# Altium schematic sheet Thruster_Power.SchDoc
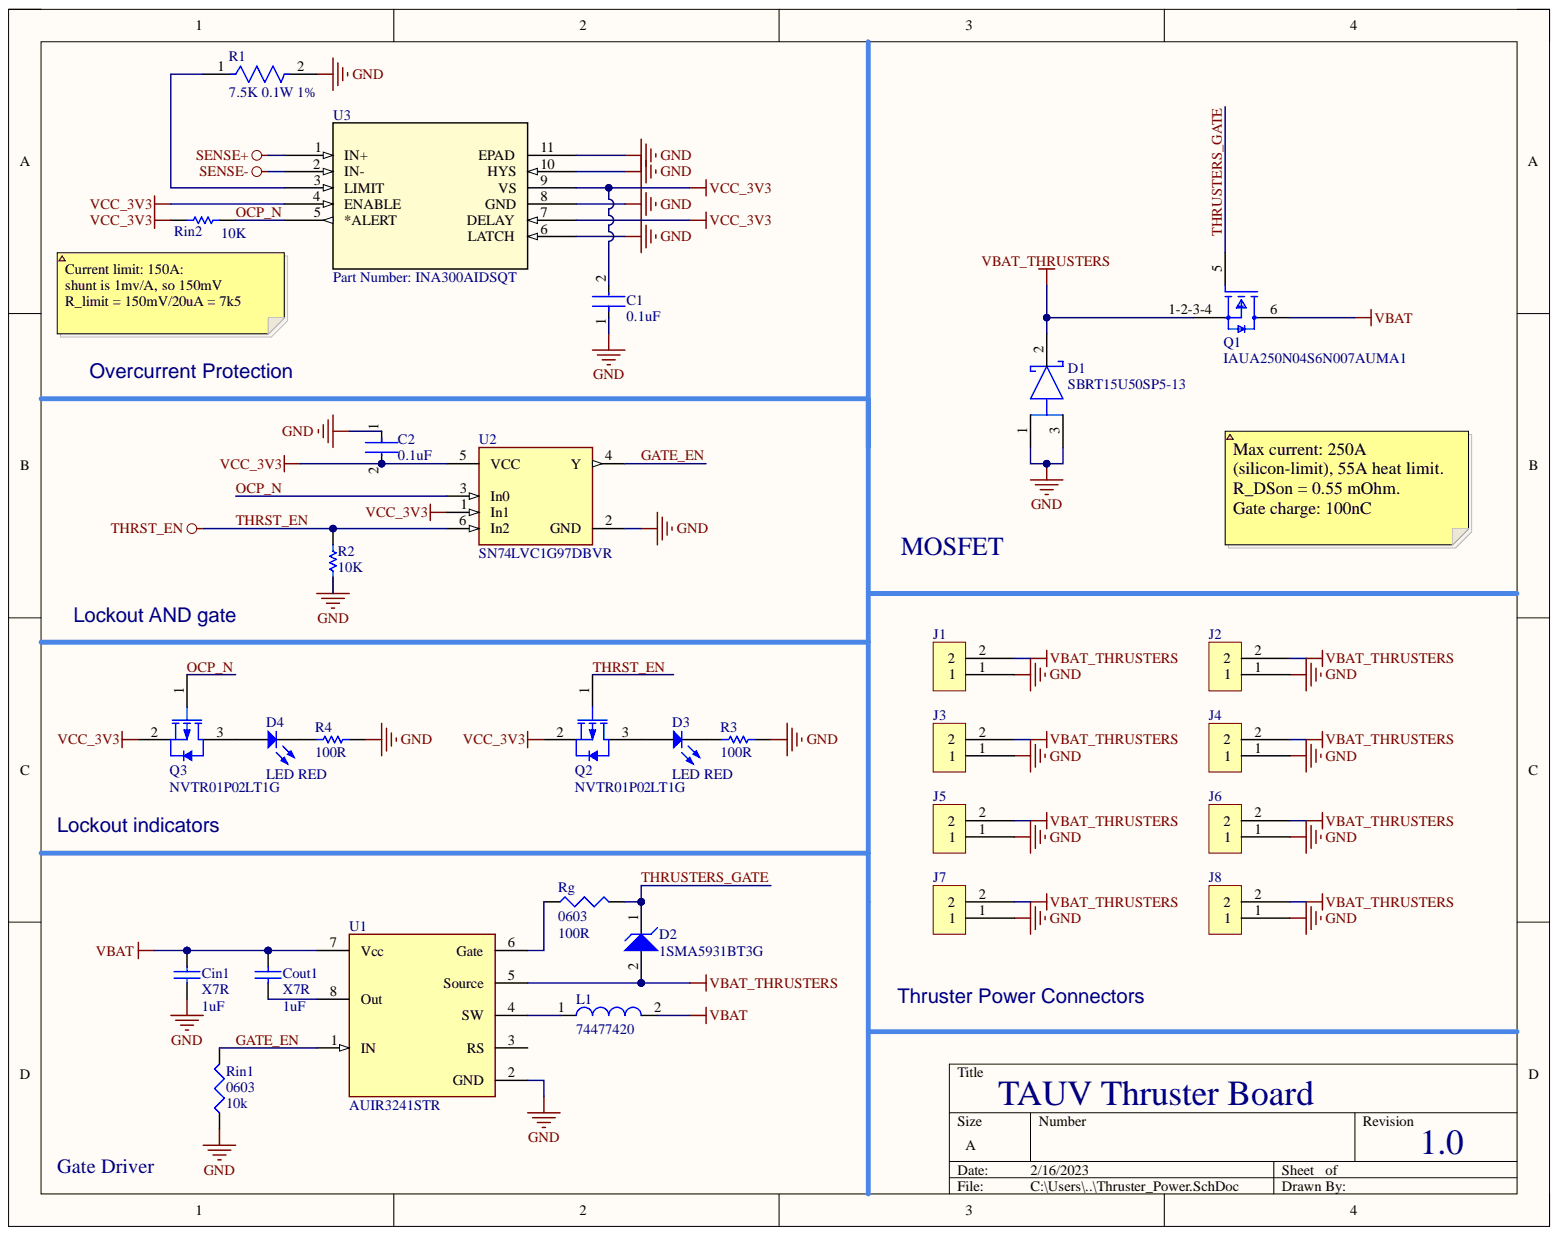
[diagram p0: Thruster_Power.SchDoc]

## Parts
C1  0.1uF  [FP-C0603C-CF-MFG]
C2  0.1uF  [FP-C0603C-CF-MFG]
Cin1  X7R  value 1uF  [C0805]
Cout1  X7R  value 1uF  [C0805]
D1  SBRT15U50SP5-13  [FP-PowerDI5-MFG]
D2  1SMA5931BT3G  [ONSC-SMA-2-403D-02_F_V]
D3  LED RED  [C0805]
D4  LED RED  [C0805]
J1  (no value)  [2-pin Megafit RA]
J2  (no value)  [2-pin Megafit RA]
J3  (no value)  [2-pin Megafit RA]
J4  (no value)  [2-pin Megafit RA]
J5  (no value)  [2-pin Megafit RA]
J6  (no value)  [2-pin Megafit RA]
J7  (no value)  [2-pin Megafit RA]
J8  (no value)  [2-pin Megafit RA]
L1  74477420  [WE-PD2-M]
Q1  IAUA250N04S6N007AUMA1  [FP-PG-HSOF-5-MFG]
Q2  NVTR01P02LT1G  [ONSC-SOT-23-3-318-08_V]
Q3  NVTR01P02LT1G  [ONSC-SOT-23-3-318-08_V]
R1  7.5K 0.1W 1%  Resistance Tolerance: 1%  [FP-ERJ3EK-MFG]
R2  10K  [RESC0603(1608)_N]
R3  100R  [RESC1609X50X30NL10T20]
R4  100R  [RESC1609X50X30NL10T20]
Rg  0603  value 100R  [J1-0603]
Rin1  0603  value 10k  [J1-0603]
Rin2  10K  [RESC0603(1608)_N]
U1  AUIR3241STR  [FP-PG-DSO-8-MFG]
U2  SN74LVC1G97DBVR  [FP-DBV0006A-MFG]
U3  Part Number: INA300AIDSQT  [DSQ10_P9X1P5]

## Nets
GND: C1.1 C2.1 Cin1.1 D1.1 D1.3 J1.1 J2.1 J3.1 J4.1 J5.1 J6.1 J7.1 J8.1 R1.2 R2.2 R3.2 R4.2 Rin1.1 U1.2(GND) U2.2(GND) U3.6(LATCH) U3.8(GND) U3.10(HYS) U3.11(EPAD)
SENSE+: U3.1(IN+)
SENSE-: U3.2(IN-)
VBAT: Cin1.2 Cout1.2 L1.2 Q1.6 U1.7(Vcc)
VBAT_THRUSTERS: D1.2 D2.2 J1.2 J2.2 J3.2 J4.2 J5.2 J6.2 J7.2 J8.2 Q1.1-2-3-4 U1.5(Source)
VCC_3V3: C1.2 C2.2 Q2.2 Q3.2 Rin2.2 U2.1(In1) U2.5(VCC) U3.4(ENABLE) U3.7(DELAY) U3.9(VS)
GATE_EN: Rin1.2 U1.1(IN) U2.4(Y)
OCP_N: Q3.1 Rin2.1 U2.3(In0) U3.5(*ALERT)
THRST_EN: Q2.1 R2.1 U2.6(In2)
THRUSTERS_GATE: D2.1 Q1.5 Rg.2
NetCout1_1: Cout1.1 U1.8(Out)
NetD3_1: D3.1 R3.1
NetD3_2: D3.2 Q2.3
NetD4_1: D4.1 R4.1
NetD4_2: D4.2 Q3.3
NetL1_1: L1.1 U1.4(SW)
NetR1_1: R1.1 U3.3(LIMIT)
NetRg_1: Rg.1 U1.6(Gate)

Not connected: U1 3(RS)

## Library data of the parts
C1 C2 = CMP-1035-02580-3: CAP CER 0.1UF 10V X7R 0603; Manufacturer: KEMET; Manufacturer Part Number: C0603C104K8RACTU; Automotive Grade: Grade 1; Capacitance: 0.10 μF; Material: Ceramic; Temperature Coefficient: 30ppm/°C; Tolerance: 0.1; Value: 0.10 μF; Automotive: Yes; Category: Cap; Component Kind: Standard; ComponentLink2Description: Datasheet; ComponentLink2URL: https://api.kemet.com/component-edge/download/datasheet/C0603C100J5GACAUTO.pdf; Device Class L1: Passive Components; Device Class L2: Capacitors; Device Class L3: Ceramic Capacitors; Digikey Description: CAP CER 0.1UF 10V X7R 0603; Height: 0.87mm; Lead Free: Yes; Library Name: Altium Content Vault; Mouser Description: Multilayer Ceramic Capacitors MLCC - SMD/SMT 10volts 0.1uF X7R 10%; Octopart: https://octopart.com/c0603c104k8ractu-kemet-145075; Package: C0603C-CF; RoHS: TRUE; Temperature: -55°C to +125°C; Temperature Characteristic: X7R; Temperature Range High: +125°C; Temperature Range Low: -55°C; Voltage Rating: 10 V
Cin1 Cout1 = Cap: Capacitor; Component Kind: Standard; LatestRevisionDate: 17-Jul-2002; LatestRevisionNote: Re-released for DXP Platform.; Library Name: Miscellaneous Devices.IntLib; PackageDescription: Radial Cap, Thru-Hole; 2 Leads; 0.3 in Pin Spacing; PackageReference: RAD-0.3; Published: 8-Jun-2000; Signal Integrity: Cap; Simulation: CAP; Publisher: Altium Limited
D1 = CMP-12791-000016-1: 50V 15A Rectifier Schottky Diode; Manufacturer: Diodes Inc.; Manufacturer Part Number: PDS3200-13; Forward Current: 3A; Max Junction Temp: +150°C; Max Repetitive Reverse Voltage: 200V; Max Surge Forward Current: 180A; Peak Reverse Current: 1uA; Standoff Height: 0mm; Automotive: No; Category: Diode; Component Kind: Standard; ComponentLink2Description: Datasheet; ComponentLink2URL: https://www.diodes.com/assets/Datasheets/ds30470.pdf; Device Class L1: Discrete Semiconductors; Device Class L2: Diodes; Device Class L3: Rectifier Diodes; Digikey Description: DIODE SCHOTTKY 200V 3A POWERDI5; Footprint Url: https://www.diodes.com/assets/Package-Files/PowerDI5.pdf; Height: 1.15mm; Lead Free: Yes; Library Name: Altium Content Vault; Mouser Description: PDS3200 Series 200 V 3 A High Voltage Schottky Barrier Rectifier - PowerDI-5; Octopart: https://octopart.com/pds3200-13-diodes+inc.-332544; Package: PowerDI5; Reverse Voltage: 200V; RoHS: TRUE; Temperature: -65°C to +150°C; Temperature Range High: +150°C; Temperature Range Low: -65°C
D2 = CMP-1060-00118-1: 1.5 W Zener Voltage Regulator, 2-Pin SMA, Pb-Free, Tape and Reel; Manufacturer: On Semiconductor; VZ Typ (V): 18; Component Kind: Standard; ComponentLink1Description: Manufacturer URL; ComponentLink1URL: http://www.onsemi.com/; ComponentLink2Description: Datasheet; ComponentLink2URL: http://www.onsemi.com/pub_link/Collateral/1SMA5913BT3-D.PDF; ComponentLink3Description: Package Specification; ComponentLink3URL: http://www.onsemi.com/pub_link/Collateral/1SMA5913BT3-D.PDF; DatasheetVersion: Rev. 8; Library Name: Altium Content Vault; Mounting Technology: Surface Mount; PackageDescription: 2-Pin Small Molded Package, J-Bend Lead, Body 2.605 x 4.315 mm; PackageReference: SMA-2-403D-02_F; PackageVersion: Rev. F, 11/2008; Packing: Tape and Reel; PartNumber: 1SMA5931BT3G; RoHS: Pb-Free
D3 D4 = LED0: led   LTST-C171KRKT; Manufacturer: Lite-On Inc.; Current - Test: 20mA; Lens Color: Colorless; Lens Style/Size: Rectangle with Flat Top, 1.40mm x 1.25mm; Lens Transparency: Clear; Millicandela Rating: 54mcd; Viewing Angle: 130°; Voltage - Forward (Vf) (Typ): 2V; Wavelength - Dominant: 631nm; Wavelength - Peak: 639nm; Category: Optoelectronics; Color: Red; Component Kind: Standard; ComponentLink1Description: http://optoelectronics.liteon.com/upload/download/DS22-2000-109/LTST-C171KRKT.pdf; ComponentLink1URL: http://optoelectronics.liteon.com/upload/download/DS22-2000-109/LTST-C171KRKT.pdf; Features: -; Height (Max): 0.80mm; Manufacturer Part Number: LTST-C171KRKT; Mounting Type: Surface Mount; Package / Case: 0805 (2012 Metric); Packaging: Cut Tape (CT); Part Status: Active; Pricing 1: 1=0.31, 10=0.225, 25=0.1656, 100=0.1183, 250=0.0804, 500=0.07096, 1000=0.05558 (USD); RoHS: RoHS Compliant; Simulation: LED0; Size / Dimension: 2.00mm L x 1.25mm W; Stock 1: 260544; Supplier 1: Digi-Key; Supplier Part Number 1: 160-1427-1-ND; Library Name: CMR_Miscellaneous_Devices.IntLib
J1-J8 = 2-pin megafit: Component Kind: Standard; Library Name: TAUV-Lib.SchLib
L1 = CMP-0227-00441-1: SMD Power Inductor WE-PD2, L = 100 µH; Inductance: 100 ÂµH; Component Kind: Standard; ComponentLink1Description: Manufacturer Link; ComponentLink1URL: http://www.we-online.com; ComponentLink2Description: Datasheet; ComponentLink2URL: http://www.we-online.de/katalog/media/pdf/74477420.pdf; DatasheetVersion: ver 2, Jun-2005; Library Name: Altium Content Vault; PackageDescription: SMD Inductor, 2-Leads, Body 5.8 x 5.2 mm; PackageReference: WE-PD2-M; PackageVersion: 2005
Q1 = CMP-32901-001165-1: MOSFET_(20V 40V) PG-HSOF-5; Component Kind: Standard; Library Name: Altium Content Vault
Q2 Q3 = CMP-1058-00752-1: Power MOSFET, -20 V, -1.3 A, P-Channel, 3-Pin SOT-23, Pb-Free, Tape and Reel; Manufacturer: On Semiconductor; Channel Polarity: P Channel; Ciss Typ (pF): 225; Configuration: Single; Coss Typ (pF): 130; Crss Typ (pF): 55; ID Max (A): 1.3; PD Max (W): 0.4; Qrr Typ (nC): 8.5; V(BR)DSS Min (V): 20; VGS Max (V): 12; VGS(th) Max (V): 1.25; Component Kind: Standard; ComponentLink1Description: Manufacturer URL; ComponentLink1URL: http://www.onsemi.com/; ComponentLink2Description: Datasheet; ComponentLink2URL: http://www.onsemi.cn/pub_link/Collateral/NTR1P02LT1-D.PDF; ComponentLink3Description: Package Specification; ComponentLink3URL: http://www.onsemi.cn/pub_link/Collateral/318-08.PDF; DatasheetVersion: Rev. 13; Library Name: Altium Content Vault; Mounting Technology: Surface Mount; Package Type: SOT-23-3; PackageDescription: 3-Pin Small Outline Transistor, Body 2.92 x 1.3 mm, Pitch 0.95 mm; PackageReference: SOT-23-3-318-08; PackageVersion: Rev. AP, 11/2009; Packing: Tape and Reel; PartNumber: NVTR01P02LT1G; RoHS: Pb-Free
R1 = CMP-2000-00636-2: RES SMD 7.5K OHM 1% 1/10W 0603; Max Power Dissipation: 100mW; Resistance: 7.5kR; Temperature Coefficient: 100ppm/°C; Voltage Rating: 75V; Voltage Rating (DC): 50V; Case Code (Imperial): 0603; Case Code (Metric): 1608; Case/Package: 0603; Component Kind: Standard; Construction: Rectangular; Contact Plating: Tin; Depth: 0.8mm; Features: Automotive AEC-Q200; Height: 0.022inch; Lead Free: Lead Free; Length: 1.6mm; Library Name: Altium Content Vault; Max Operating Temperature: 155°C; Military Standard: Not; Min Operating Temperature: -55°C; Mount: Surface Mount; Number of Terminations: 2; Package Quantity: 1; Packaging: Tape and Reel; Power Rating: 100mW; Radiation Hardening: No; REACH SVHC: No SVHC; Resistor Type: Thick Film; RoHS Compliant: Yes; Series: ERJ; Termination: SMD/SMT; Tolerance: 1%; Width: 0.8mm
R2 Rin2 = CMP-1012-00098-1: 10K 0.1W 5% 0603 (1608 Metric)  SMD; Case-EIA: 0603; Case-Metric: 1608; Value: 10000.00 Ohm; Component Kind: Standard; Library Name: Altium Content Vault; Power: 0.1 W; Technology: SMT; Tolerance: 5 %
R3 R4 = CMP-1012-00050-2: 100R 0.1W 5%; Manufacturer: Vishay Dale; Part Number: CRCW0603100RJNTA; Component Kind: Standard; Library Name: Altium Content Vault
Rg Rin1 = Res3: Resistor; Component Kind: Standard; LatestRevisionDate: 29-May-2009; LatestRevisionNote: IPC-7351 Footprint Added.; Library Name: Miscellaneous Devices.IntLib; PackageDescription: Chip Resistor; PackageReference: J1-0603; Published: 8-Jun-2000; Signal Integrity: Res; Simulation: RESISTOR; Publisher: Altium Limited
U1 = CMP-32851-000034-1: IC GATE DRVR HIGH-SIDE 8SO; Number of Drivers: 1; Output Current: 300mA; Case/Package: SOIC; Component Kind: Standard; Halogen Free: Halogen Free; Lead Free: Lead Free; Library Name: Altium Content Vault; Max Operating Temperature: 150°C; Min Operating Temperature: -40°C; Mount: Surface Mount; Number of Pins: 8; Packaging: Cut Tape; RoHS Compliant: Yes
U2 = CMP-0859-00234-4: IC CONFIG MULT-FUNC GATE SOT23-6; Manufacturer: Texas Instruments; Logic Function Desc: Dual; Max Junction Temp: +150°C; Max Supply Voltage: 5.5V; Min Supply Voltage: 1.65V; Nominal Supply Current: 10uA; Number Of Circuits: 1; Number Of Inputs: 3; Propagation Delay: 6.1ns; Standoff Height: 0mm; Ambient Temperature Range High: +125°C; Ambient Temperature Range Low: -40°C; Automotive: No; Category: IC; Component Kind: Standard; ComponentLink2Description: Datasheet; ComponentLink2URL: http://www.ti.com/lit/ds/symlink/sn74lvc1g97.pdf; Device Class L1: Integrated Circuits (ICs); Device Class L2: Logic ICs; Device Class L3: Logic Gates; Digikey Description: IC CONFIG MULT-FUNC GATE SOT23-6; Height: 1.45mm; Ipc Land Pattern Name: SOT95P280X145-6; Lead Free: Yes; Library Name: Altium Content Vault; Manufacturer Part Number: SN74LVC1G97DBVR; Mouser Description: Logic Gates Cnfgrble Mult Function Gate; Number Of Outputs: 1; Octopart: https://octopart.com/sn74lvc1g97dbvr-texas+instruments-464842; Package: DBV0006A; RoHS: TRUE; Temperature: -40°C to +125°C; Temperature Range High: +125°C; Temperature Range Low: -40°C
U3 = INA300AIDSQT: No Description Available; Built_By: EMA_UL_Team; Vendor: Texas Instruments; Component Kind: Standard; Manufacturer_Part_Number: INA300AIDSQT; RefDes: RefDes; Type: DEV; Value: Value; Copyright: Copyright (C) 2018 Accelerated Designs. All rights reserved; Library Name: Tauv-Lib.IntLib
